# Altium schematic sheet Main.SchDoc(Main)
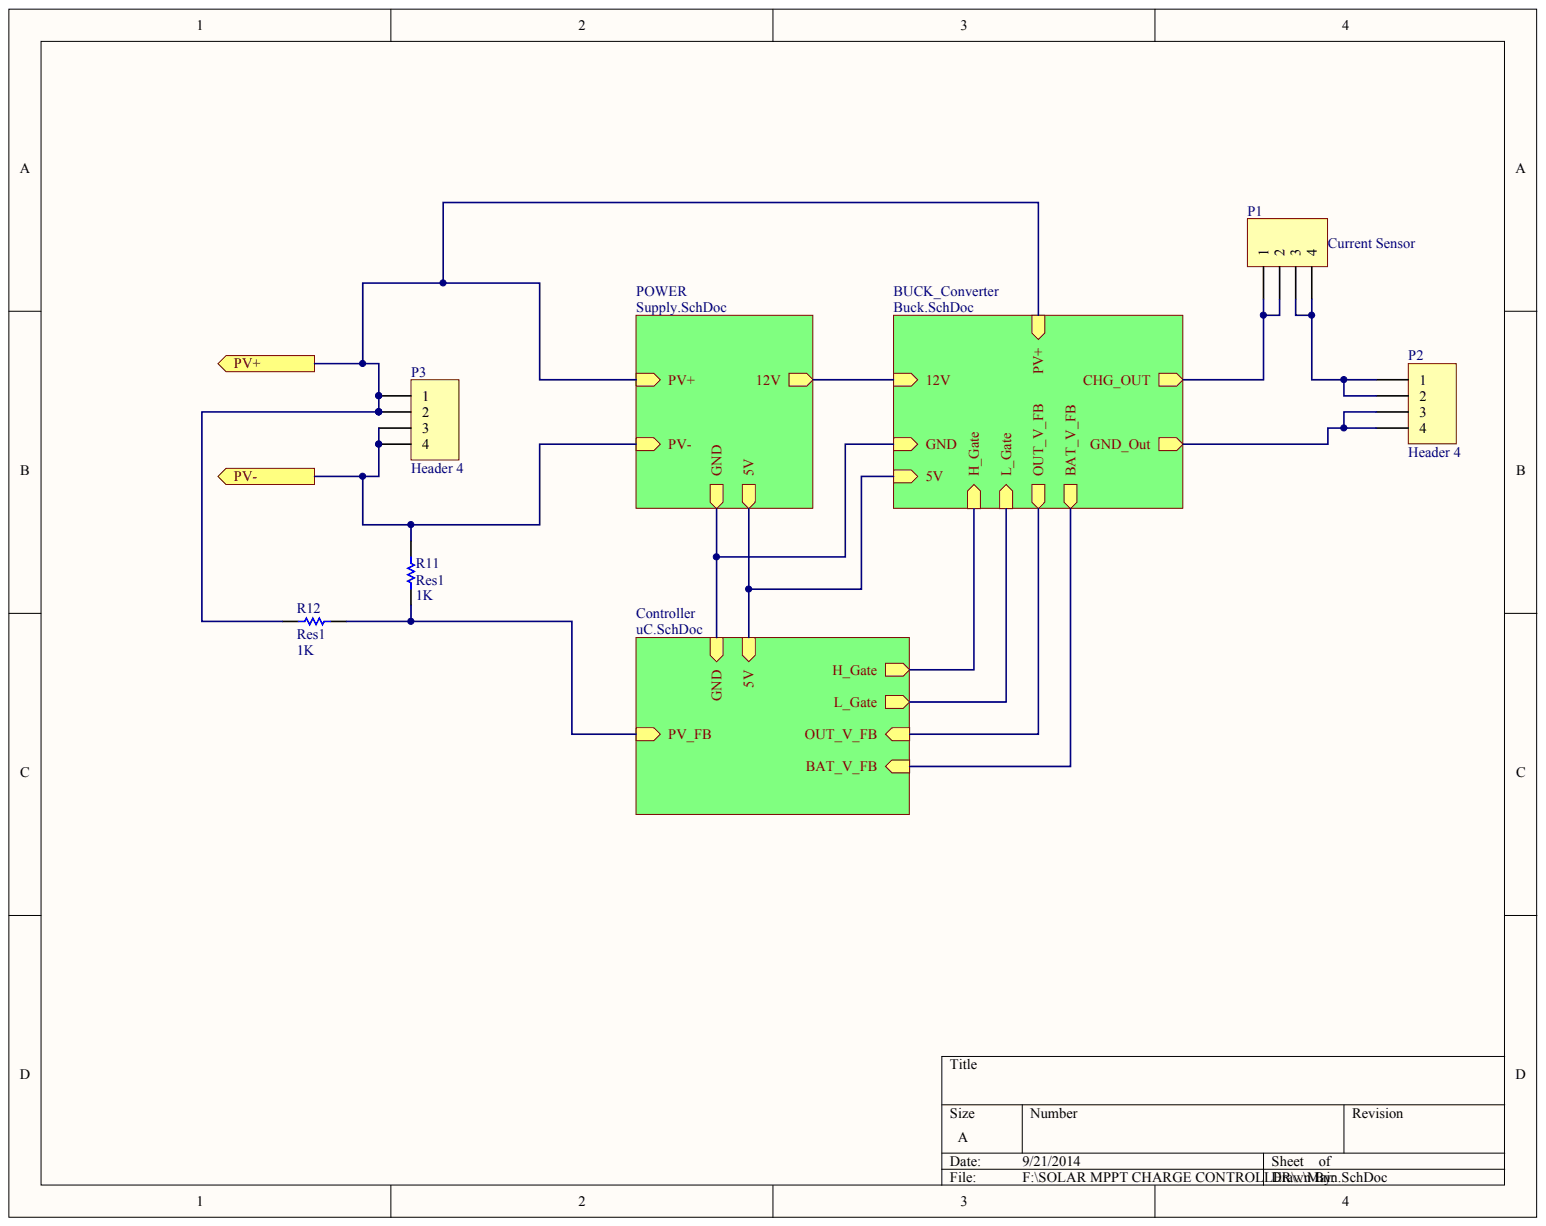
[diagram p0: Main.SchDoc]

## Parts
P1  Current Sensor
P2  Header 4
P3  Header 4
R11  Res1  value 1K
R12  Res1  value 1K

## Ports
PV+, PV-

## Nets
NetP1_1: P1.1 P1.2
NetP1_3: P1.3 P1.4 P2.1 P2.2
NetP2_3: P2.3 P2.4
NetP3_1: P3.1 P3.2 R12.2
NetP3_3: P3.3 P3.4 R11.2
NetR11_1: R11.1 R12.1
5V:
12V:
BAT_V_FB:
GND:
H_GATE:
L_GATE:
OUT_V_FB:

## Library data of the parts
P1-P3 R11 R12 = (no symbol name): LatestRevisionDate: 17-Jul-2002; LatestRevisionNote: Re-released for DXP Platform.; Publisher: Altium Limited
  R11 R12: Published: 8-Jun-2000; PackageReference: AXIAL-0.3; PackageDescription: Axial Device, Thru-Hole; 2 Leads; 0.3 in Pin Spacing
